# Altium schematic sheet gff_int_adapter_rev0.SchDoc(gff_int_adapter_rev0)
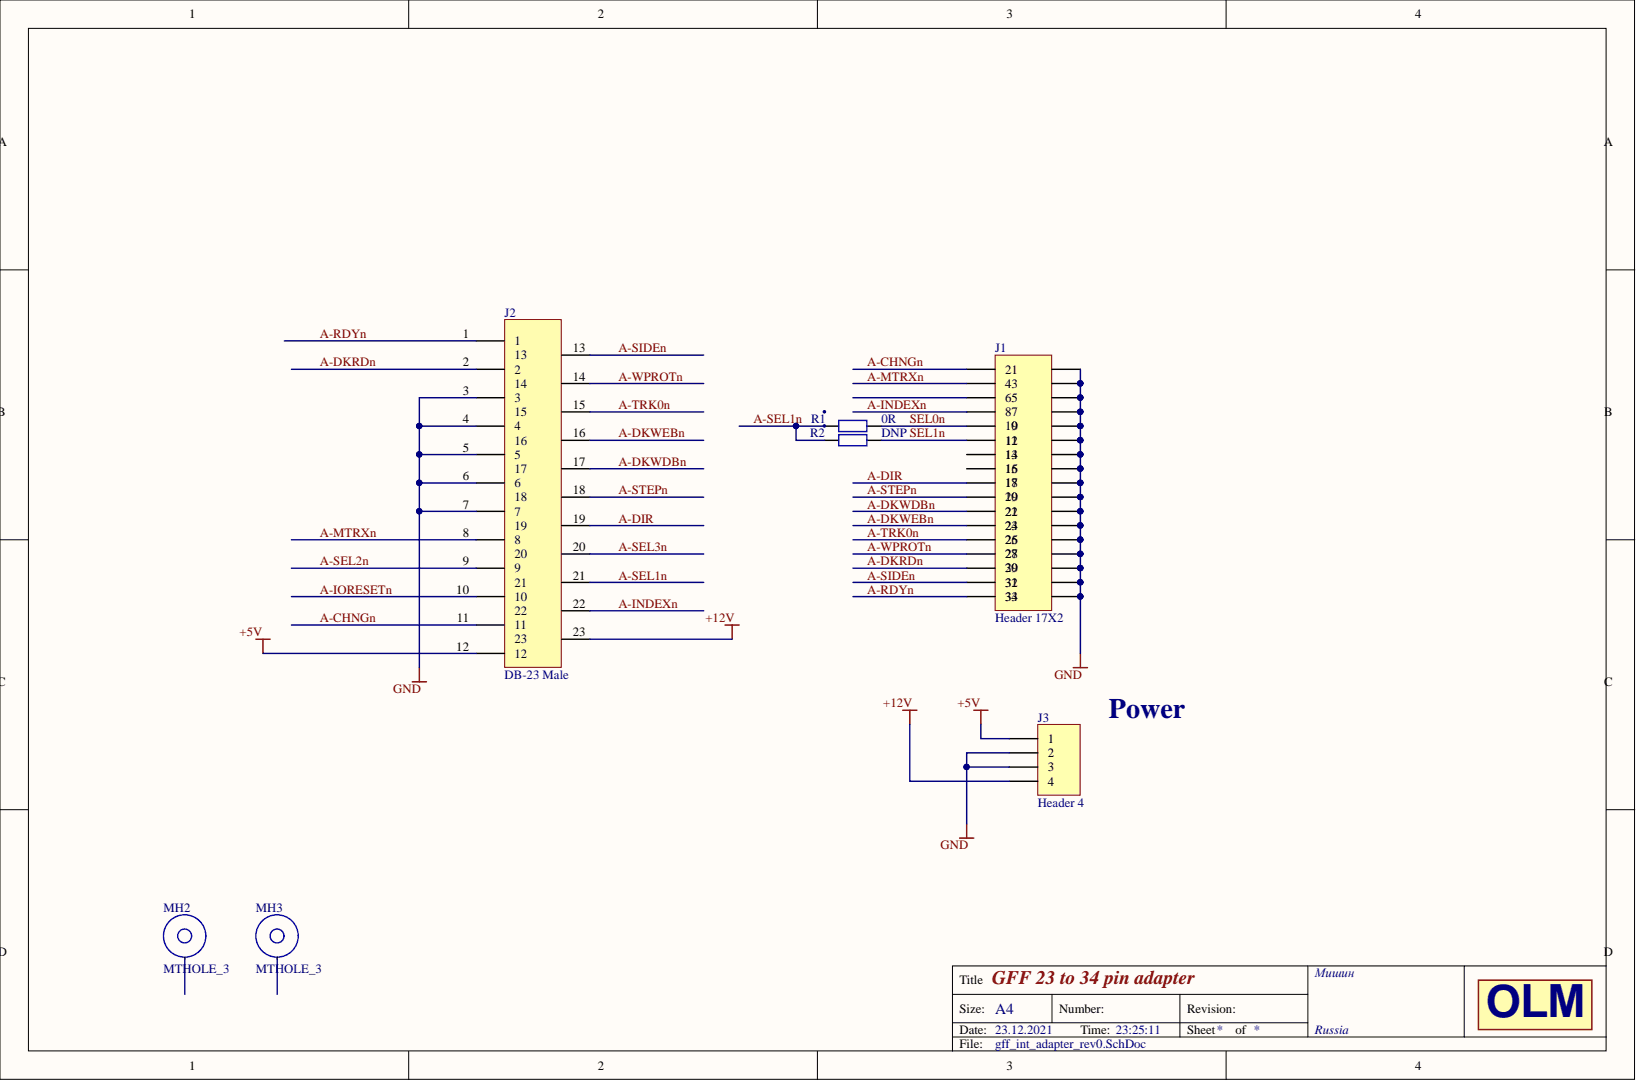
[diagram p0: gff_int_adapter_rev0.SchDoc]

## Parts
J1  Header 17X2  [HDR2X17]
J2  value DB-23 Male  Part: DB-23 Male  [SM/DB-23_EDGE]  (hidden comment: Connector)
J3  Header 4  [HDR1X4]
MH2  MTHOLE_3  [MTHOLE_3.5MM/5MM]  (no BOM)
MH3  MTHOLE_3  [MTHOLE_3.5MM/5MM]  (no BOM)
R1  value 0R  [SM/R_0603]  (hidden comment: Resistor)
R2  value DNP  [SM/R_0603]  (hidden comment: Resistor)

## Nets
+5V: J2.12 J3.1
+12V: J2.23 J3.4
GND: J1.1 J1.3 J1.5 J1.7 J1.9 J1.11 J1.13 J1.15 J1.17 J1.19 J1.21 J1.23 J1.25 J1.27 J1.29 J1.31 J1.33 J2.3 J2.4 J2.5 J2.6 J2.7 J3.2 J3.3
A-CHNGN: J1.2(2_1) J2.11
A-DIR: J1.18 J2.19
A-DKRDN: J1.30 J2.2
A-DKWDBN: J1.22 J2.17
A-DKWEBN: J1.24 J2.16
A-INDEXN: J1.8(8_7) J2.22
A-IORESETN: J2.10
A-MTRXN: J1.4(4_3) J2.8
A-RDYN: J1.34 J2.1
A-SEL1N: J2.21 R1.1 R2.1
A-SEL2N: J2.9
A-SEL3N: J2.20
A-SIDEN: J1.32 J2.13
A-STEPN: J1.20 J2.18
A-TRK0N: J1.26 J2.15
A-WPROTN: J1.28 J2.14
SEL0N: J1.10 R1.2
SEL1N: J1.12 R2.2

Not connected: J1 6(6_5) 14 16; MH2 1; MH3 1

## Library data of the parts
J1 = Header 17X2: Header, 17-Pin, Dual row; Component Kind: Standard; LatestRevisionDate: 17-Jul-2002; LatestRevisionNote: Re-released for DXP Platform.; Library Name: Miscellaneous Connectors.IntLib; Signal Integrity: Connector; Publisher: Altium Limited
J2 = DB-23: Component Kind: Standard; Library Name: Connectors_home.SchLib
J3 = Header 4: Header, 4-Pin; Component Kind: Standard; LatestRevisionDate: 17-Jul-2002; LatestRevisionNote: Re-released for DXP Platform.; Library Name: Miscellaneous Connectors.IntLib; Signal Integrity: Connector; Publisher: Altium Limited
R1 R2 = Res2: Part: 0R 5% 0603; Component Kind: Standard; Description: Resistor; LatestRevisionDate: 17-Jul-2002; LatestRevisionNote: Re-released for DXP Platform.; Library Name: Miscellaneous Devices.IntLib; PackageReference: AXIAL-0.4; Published: 8-Jun-2000; Signal Integrity: Res; Simulation: RESISTOR; Publisher: Altium Limited
MH2 MH3 = MTHOLE_3: Library Name: New_home.SCHLIB
